# Altium schematic sheet CP2102.SchDoc
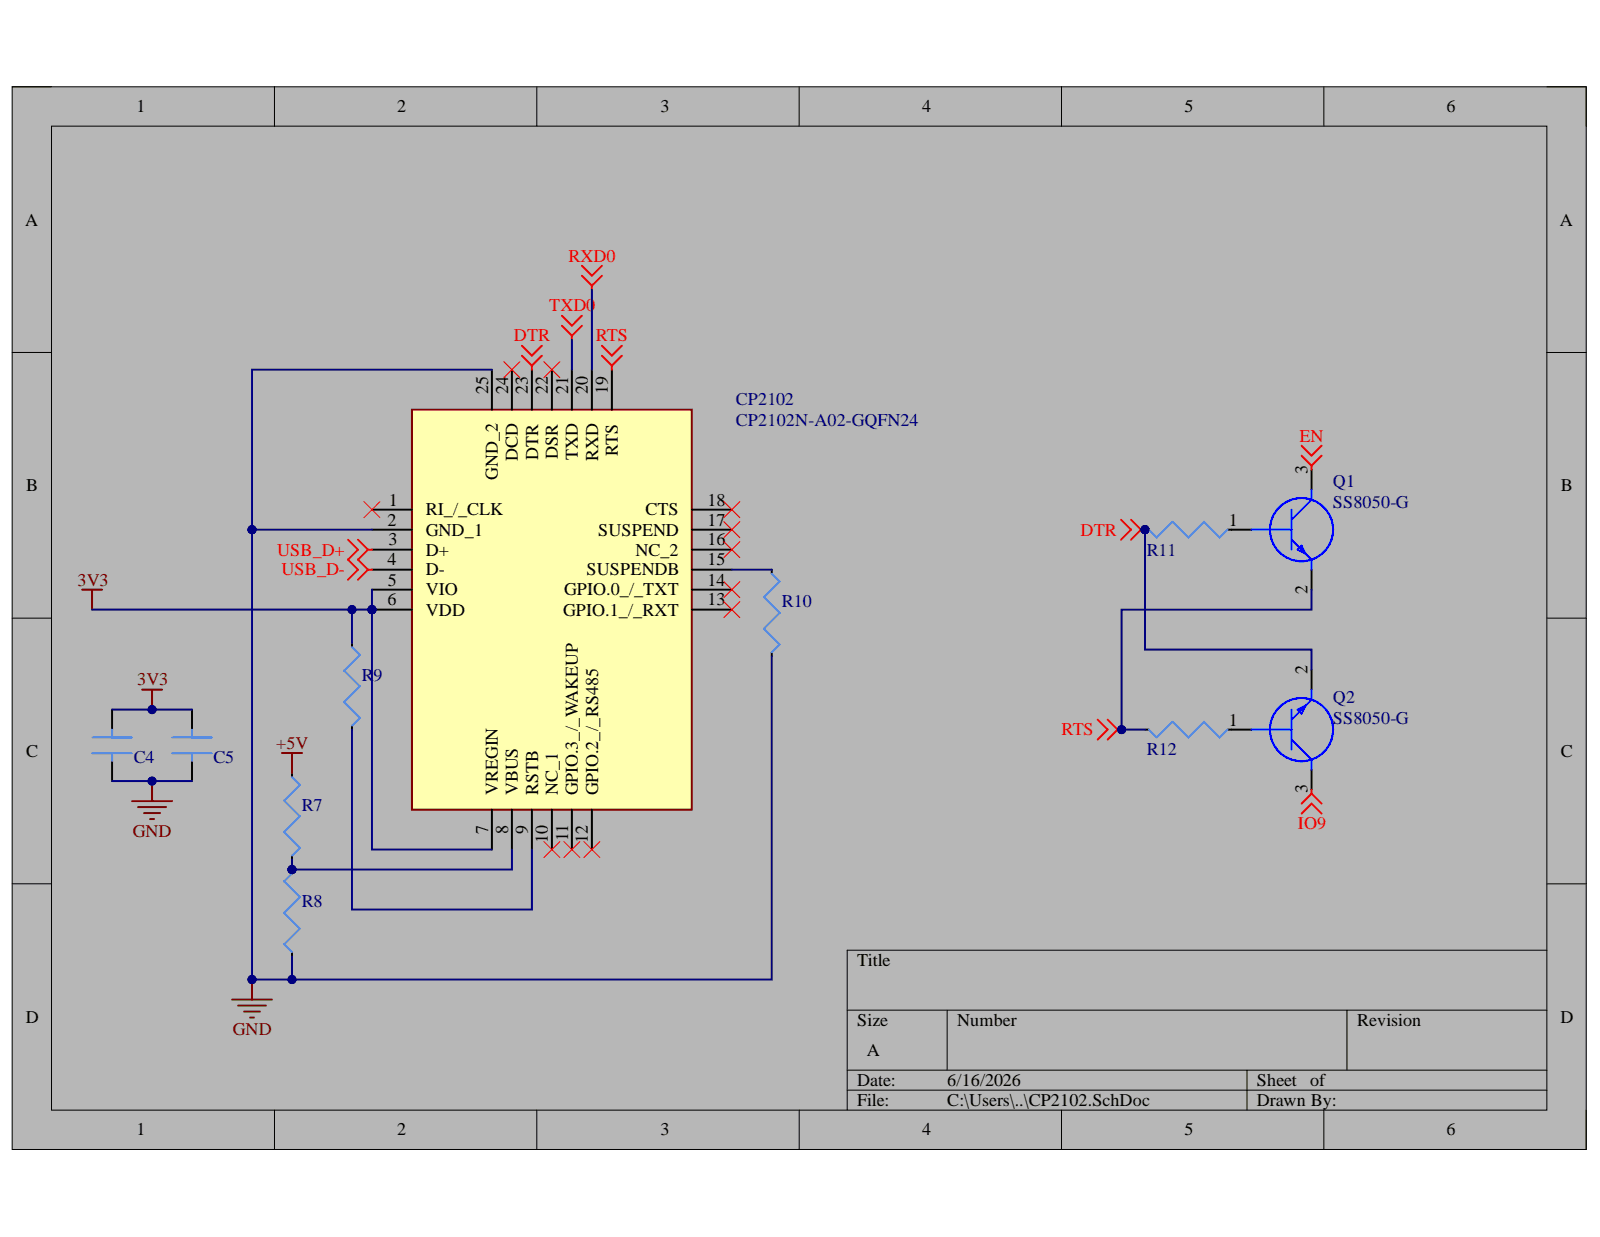
[diagram p0: CP2102.SchDoc]

## Parts
C4  0.1uF 0402  [0402 Cap]  (value not drawn)
C5  10uF 0402  [0402 Cap]  (value not drawn)
CP2102  CP2102N-A02-GQFN24  [QFN50P400X400X80-25N-D]
Q1  SS8050-G  [SOT95P240X115-3N]
Q2  SS8050-G  [SOT95P240X115-3N]
R7  22.1K Ohm 5% 0402  [0402_RESISTOR]  (value not drawn)
R8  47.5K Ohm 5% 0402  [0402_RESISTOR]  (value not drawn)
R9  2K Ohm 5% 0402  [0402_RESISTOR]  (value not drawn)
R10  10K Ohm 5% 0402  [0402_RESISTOR]  (value not drawn)
R11  10K Ohm 5% 0402  [0402_RESISTOR]  (value not drawn)
R12  10K Ohm 5% 0402  [0402_RESISTOR]  (value not drawn)

## Nets
3V3: C4.2 C5.2 CP2102.5(VIO) CP2102.6(VDD) CP2102.7(VREGIN) R9.2
+5V: R7.2
DTR: CP2102.23(DTR) Q2.2 R11.1
EN: Q1.3
GND: C4.1 C5.1 CP2102.2(GND_1) CP2102.25(GND_2) R8.2 R10.1
IO9: Q2.3
RTS: CP2102.19(RTS) Q1.2 R12.1
RXD0: CP2102.20(RXD)
TXD0: CP2102.21(TXD)
USB_D+: CP2102.3(D+)
USB_D-: CP2102.4(D-)
NetCP2102_8: CP2102.8(VBUS) R7.1 R8.1
NetCP2102_9: CP2102.9(RSTB) R9.1
NetCP2102_15: CP2102.15(SUSPENDB) R10.2
NetQ1_1: Q1.1 R11.2
NetQ2_1: Q2.1 R12.2

Not connected: CP2102 1(RI_/_CLK) 10(NC_1) 11(GPIO.3_/_WAKEUP) 12(GPIO.2_/_RS485) 13(GPIO.1_/_RXT) 14(GPIO.0_/_TXT) 16(NC_2) 17(SUSPEND) 18(CTS) 22(DSR) 24(DCD)

## Library data of the parts
C4 C5 = Cap_0402: Component Kind: Standard; Library Name: custom.SchLib
CP2102 = CP2102N-A02-GQFN24: Integrated Circuit; Manufacturer_Name: Silicon Labs; Arrow Part Number: CP2102N-A02-GQFN24; Arrow Price/Stock: https://www.arrow.com/en/products/cp2102n-a02-gqfn24/silicon-labs?utm_currency=USD&region=nac; Component Kind: Standard; Datasheet Link: https://componentsearchengine.com/Datasheets/1/CP2102N-A02-GQFN24.pdf; Height: 0.8mm; Library Name: SamacSys.SchLib; Manufacturer_Part_Number: CP2102N-A02-GQFN24; Mouser Part Number: 634-CP2102NA02GQFN24; Mouser Price/Stock: https://www.mouser.co.uk/ProductDetail/Silicon-Labs/CP2102N-A02-GQFN24?qs=u16ybLDytRYKabtL%2FE7DZA%3D%3D
Q1 Q2 = SS8050-G: Transistor BJT NPN; Manufacturer_Name: Comchip Technology; Arrow Part Number: SS8050-G; Arrow Price/Stock: https://www.arrow.com/en/products/ss8050-g/comchip-technology?region=nac; Component Kind: Standard; Datasheet Link: https://datasheet.datasheetarchive.com/originals/distributors/DKDS-12/229454.pdf; Height: 1.15mm; Manufacturer_Part_Number: SS8050-G; Mouser Part Number: 750-SS8050-G; Mouser Price/Stock: https://www.mouser.co.uk/ProductDetail/Comchip-Technology/SS8050-G?qs=LLUE9lz1YbcHg%252BWLMAtcrQ%3D%3D
R7-R12 = Resistor_0402: Component Kind: Standard; Library Name: custom.SchLib
